# Altium schematic sheet 06_DRIVER.SchDoc
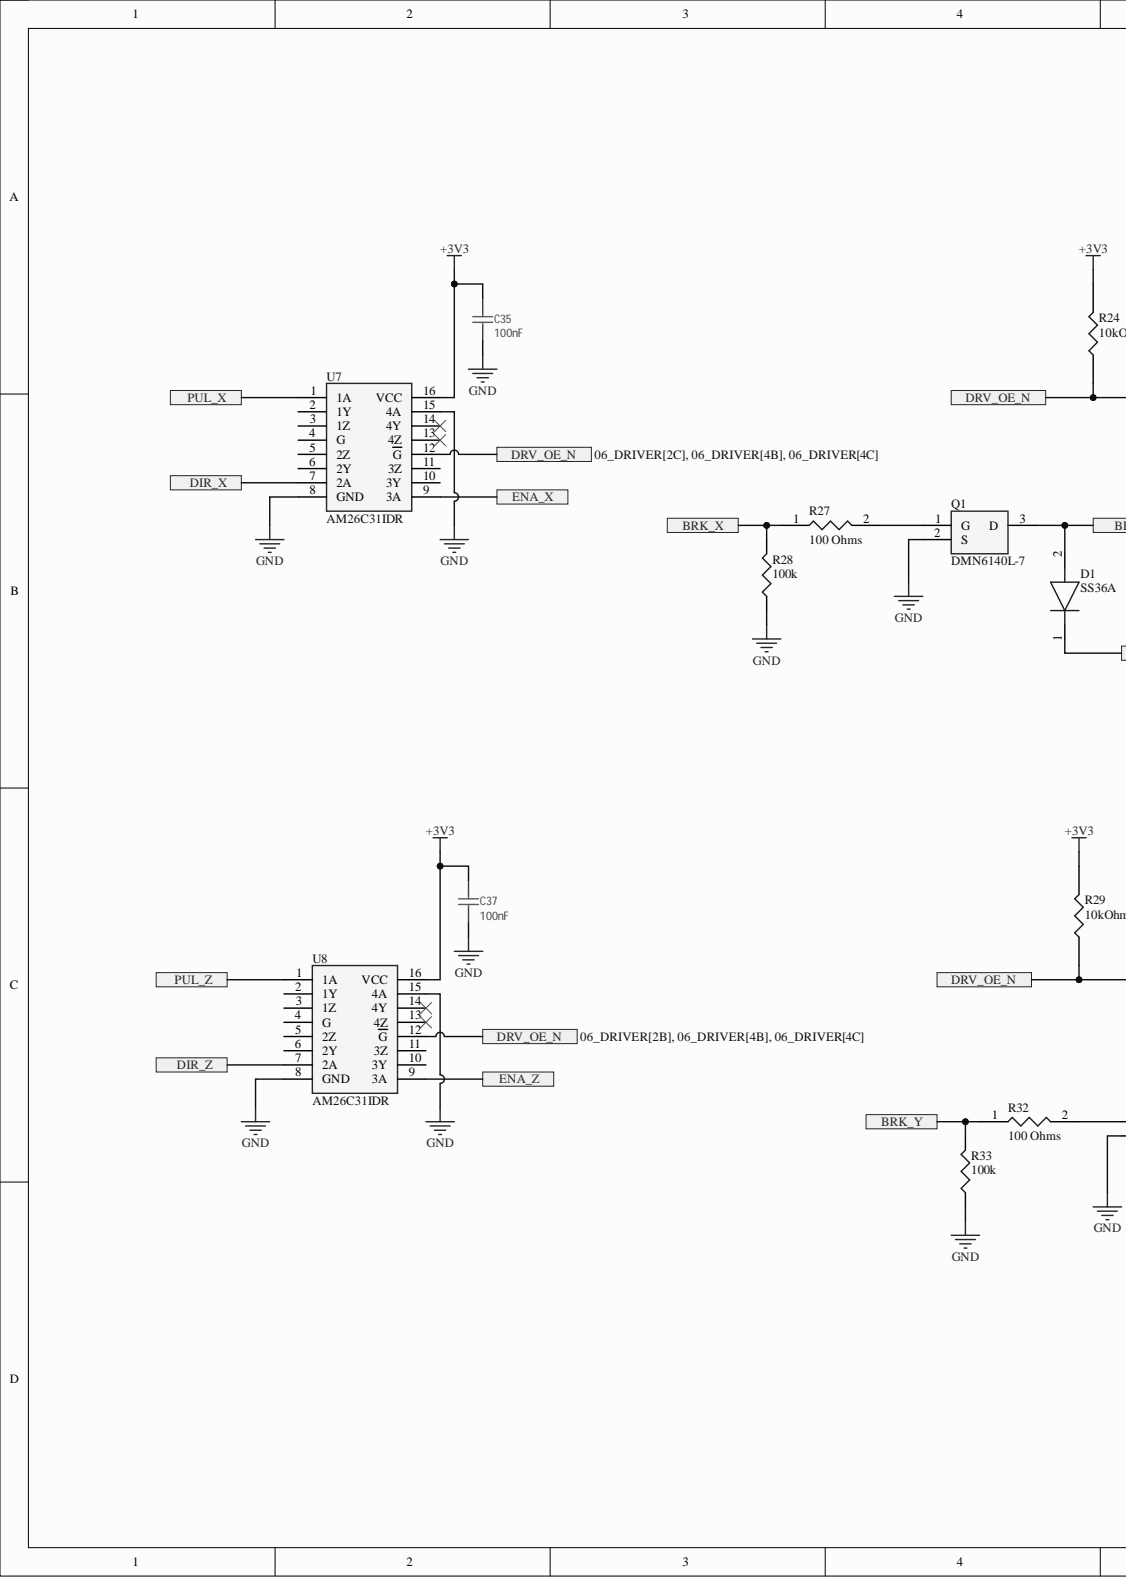
[diagram p0: 06_DRIVER.SchDoc, left]
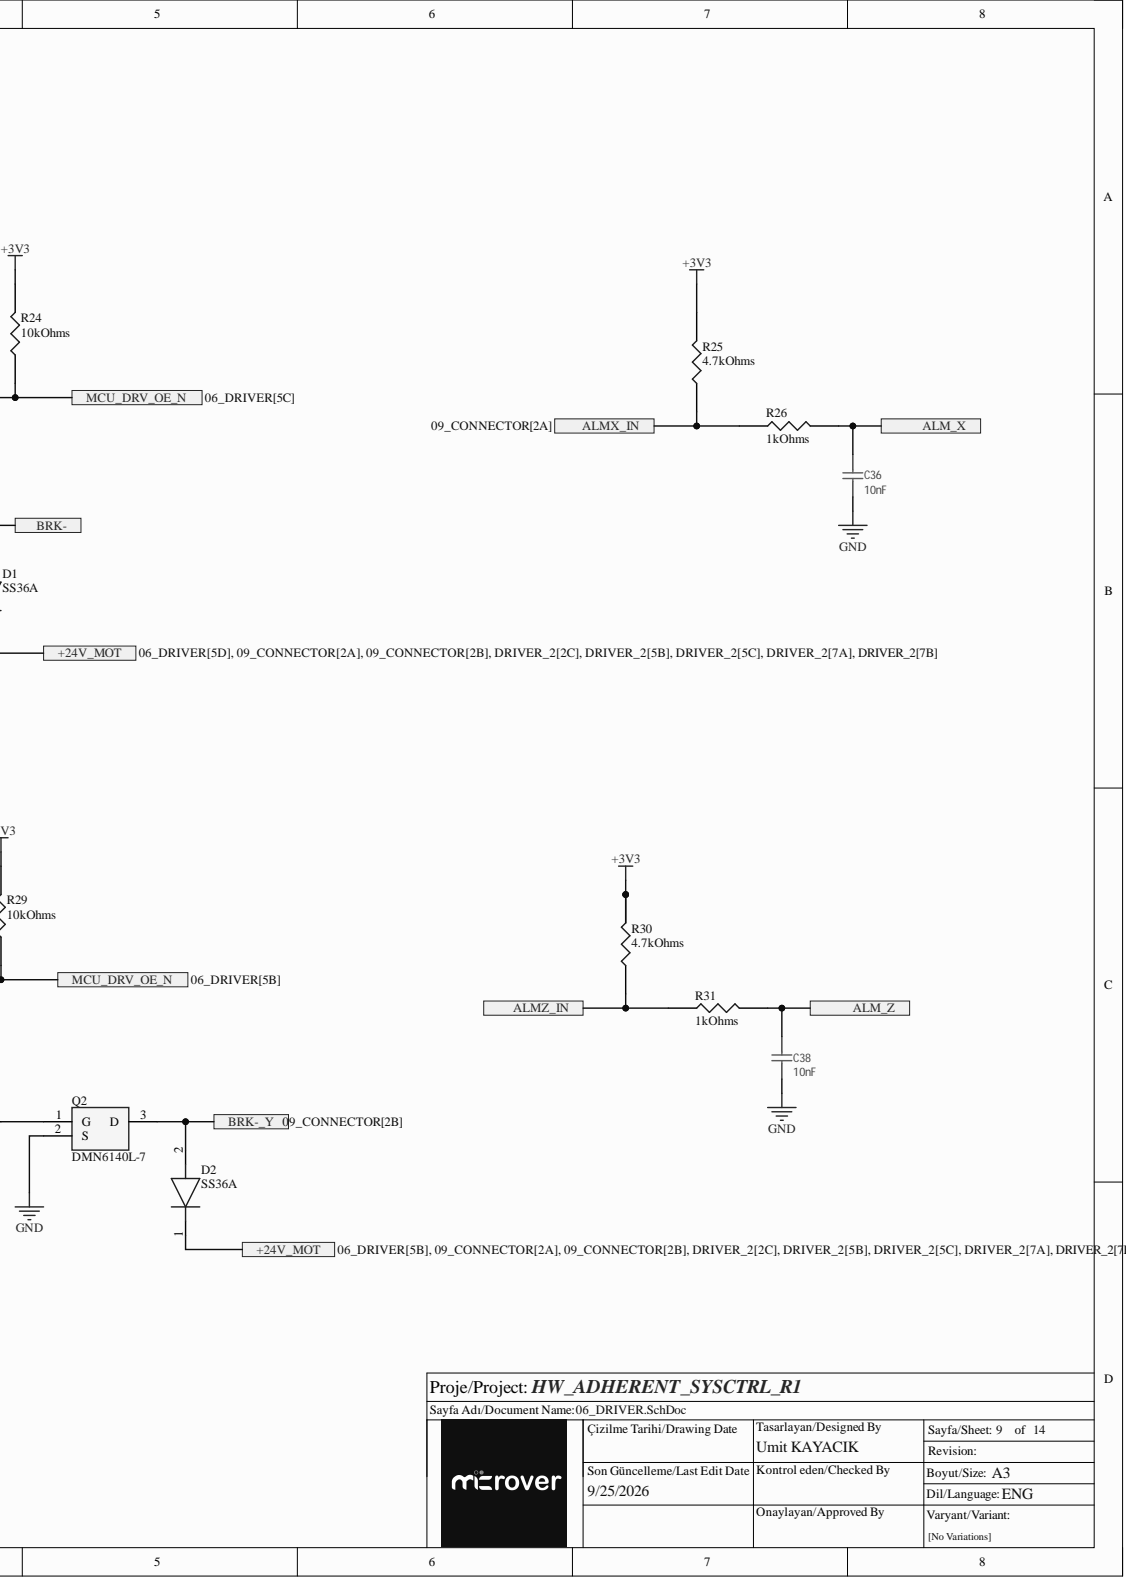
[diagram p1: 06_DRIVER.SchDoc, right]

## Parts
C35  100nF  [CAPC0603X03L_C]
C36  10nF  [CAPC0603X03L_C]
C37  100nF  [CAPC0603X03L_C]
C38  10nF  [CAPC0603X03L_C]
D1  SS36A  [DIOM5026X262N]
D2  SS36A  [DIOM5026X262N]
Q1  DMN6140L-7  [SOT96P240X110-3N]
Q2  DMN6140L-7  [SOT96P240X110-3N]
R24  10kOhms  [RESC0603X03L_C]
R25  4.7kOhms  [RES_0402]
R26  1kOhms  [RESC0603X03L_C]
R27  100 Ohms  [RESC1608X55N]
R28  value 100k  [SMR0603]  (hidden comment: RES 180K OHM 1% 1/10W 0603)
R29  10kOhms  [RESC0603X03L_C]
R30  4.7kOhms  [RES_0402]
R31  1kOhms  [RESC0603X03L_C]
R32  100 Ohms  [RESC1608X55N]
R33  value 100k  [SMR0603]  (hidden comment: RES 180K OHM 1% 1/10W 0603)
U7  AM26C31IDR  [SOIC127P600X175-16N]
U8  AM26C31IDR  [SOIC127P600X175-16N]

## Ports
+24V_MOT, ALM_X, ALM_Z, ALMX_IN, ALMZ_IN, BRK-, BRK-_Y, BRK_X, BRK_Y, DIR_X, DIR_Z, DRV_OE_N, ENA_X, ENA_Z, MCU_DRV_OE_N, PUL_X, PUL_Z

## Nets
+3V3: C35.2 C37.2 R24.2 R25.2 R29.2 R30.2 U7.16(VCC) U8.16(VCC)
GND: C35.1 C36.1 C37.1 C38.1 Q1.2 Q2.2 R28.1 R33.1 U7.8(GND) U7.15(4A) U8.8(GND) U8.15(4A)
+24V_MOT: D1.1 D2.1 ; port +24V_MOT
ALM_X: C36.2 R26.2 ; port ALM_X
ALM_Z: C38.2 R31.2 ; port ALM_Z
ALMX_IN: R25.1 R26.1 ; port ALMX_IN
ALMZ_IN: R30.1 R31.1 ; port ALMZ_IN
BRK-: D1.2 Q1.3 ; port BRK-
BRK-_Y: D2.2 Q2.3 ; port BRK-_Y
BRK_X: R27.1 R28.2 ; port BRK_X
BRK_Y: R32.1 R33.2 ; port BRK_Y
DIR_X: U7.7(2A) ; port DIR_X
DIR_Z: U8.7(2A) ; port DIR_Z
DRV_OE_N: R24.1 R29.1 U7.12(G) U8.12(G) ; port DRV_OE_N, port MCU_DRV_OE_N
ENA_X: U7.9(3A) ; port ENA_X
ENA_Z: U8.9(3A) ; port ENA_Z
PUL_X: U7.1(1A) ; port PUL_X
PUL_Z: U8.1(1A) ; port PUL_Z
NetQ1_1: Q1.1 R27.2
NetQ2_1: Q2.1 R32.2

Not connected: U7 2(1Y) 3(1Z) 4(G) 5(2Z) 6(2Y) 10(3Y) 11(3Z) 13(4Z) 14(4Y); U8 2(1Y) 3(1Z) 4(G) 5(2Z) 6(2Y) 10(3Y) 11(3Z) 13(4Z) 14(4Y)

## Library data of the parts
C35 C37 = C0201-100n: Capacitor, X5R, ±10%; Part number IPS: 00000001248, 00000002505; Part number NEMS: 100200078; Part number Norautron: 262071862; Artikkelnr Noca: N.A.; Component Status: Active; Note: 16V; Pad Count: 2; Component Class: Capacitors; Component Kind: Standard; ComponentLink1Description: Datasheet; ComponentLink1URL: N.A.; ComponentLink2Description: Supplier link; ComponentLink2URL: N.A.; Footprint Doc: 0201; HelpURL: N.A.; LatestRevisionDate: 2017-11-09; Manufacturer: N.A.; Manufacturer Part Number: N.A.; Part Number: C0201-100n; PartId: 100200078; Published: 2014-11-13; Supplier 1: N.A.; Supplier 2: N.A.; Supplier Part Number 1: N.A.; Supplier Part Number 2: N.A.; Value: 100nF; Author: rsk; Library Name: HW_ADHERENT_SYSCTRL_R1_L1.SchLib
C36 C38 = C0201-10n: Capacitor, X7R, ±10%; Part number IPS: 00000003480; Part number NEMS: 100200067; Part number Norautron: 26621779; Artikkelnr Noca: N.A.; Component Status: Active; Note: 10V; Pad Count: 2; Component Class: Capacitors; Component Kind: Standard; ComponentLink1Description: Datasheet; ComponentLink1URL: N.A.; ComponentLink2Description: Supplier link; ComponentLink2URL: N.A.; Footprint Doc: 0201; HelpURL: N.A.; LatestRevisionDate: 2017-11-09; Manufacturer: N.A.; Manufacturer Part Number: N.A.; Part Number: C0201-10n; PartId: 100200067; Published: 2014-11-13; Supplier 1: N.A.; Supplier 2: N.A.; Supplier Part Number 1: N.A.; Supplier Part Number 2: N.A.; Value: 10nF; Author: rsk; Library Name: HW_ADHERENT_SYSCTRL_R1_L1.SchLib
D1 D2 = SS36A: Diode; Manufacturer_Name: HY Electronic; Component Kind: Standard; Height: 2.62mm; Manufacturer_Part_Number: SS36A
Q1 Q2 = DMN6140L-7: Transistor; Manufacturer_Name: Diodes Incorporated; Arrow Part Number: DMN6140L-7; Arrow Price/Stock: https://www.arrow.com/en/products/dmn6140l-7/diodes-incorporated?utm_currency=USD&region=asia; Component Kind: Standard; Datasheet Link: https://www.diodes.com//assets/Datasheets/DMN6140L.pdf; Height: 1.1mm; Manufacturer_Part_Number: DMN6140L-7; Mouser Part Number: 621-DMN6140L-7; Mouser Price/Stock: https://www.mouser.co.uk/ProductDetail/Diodes-Incorporated/DMN6140L-7?qs=M%2FOdCRO8QQ0gki98nN7lXQ%3D%3D
R24 R29 = TNPW060310K0FHTA: 10 kOhms ±1% 0.1W, 1/10W Chip Resistor 0603 (1608 Metric) Automotive AEC-Q200 Thin Film; Manufacturer: Vishay Dale; Component Kind: Standard; Supplier: Digi; 541-3906-6-ND; Library Name: HW_ADHERENT_SYSCTRL_R1_L1.SchLib
R25 R30 = RT0402FRE074K7L: RES SMD 4.7K OHM 1% 1/16W 0402; Manufacturer: Yageo Group; Max Power Dissipation: 63mW; Power Rating: 62.5mW; Temperature Coefficient: 50ppm/°C; Voltage Rating: 50V; Case Code (Imperial): 0402; Case/Package: 0402; Category: Chip SMD Resistors; China RoHS: Non-Compliant; Component Kind: Standard; Composition: Thin Film; Contact Plating: Lead, Tin; Country of Origin: Mainland China; Export Control Classification Number (ECCN) Code: EAR99; Features: Moisture Resistant; Harmonized Tariff Schedule (HTS) Code: 8533.21.00.30; Height: 300µm; Introduction Date: 2008-06-09; Lead Free: Lead Free; Length: 1mm; Lifecycle Status: Production; Manufacturer Part Number: RT0402FRE074K7L; Max Operating Temperature: 155°C; Min Operating Temperature: -55°C; Mounting Technology: Surface Mount; Number of Terminals: 2; Number of Terminations: 2; Radiation Hardening: No; REACH SVHC: Yes; RoHS: Compliant; Schedule B: 8533210045, 8533210045|8533210045, 8533210045|8533210045|8533210045, 8533210045|8533210045|8533210045|8533210045; Termination: SMD/SMT; Tolerance: 1%; Value: 4.7kOhms; Voltage Rating (DC): 50V; Width: 500µm; Working Voltage: 50V; Library Name: HW_ADHERENT_SYSCTRL_R1_L1.SchLib
R26 R31 = CPF0603B1K0E: RES SMD 1K OHM 0.1% 1/16W 0603; Manufacturer: TE Connectivity; Manufacturer Lifecycle Status: ACTIVE; Max Power Dissipation: 63mW; Number of Resistors: 1; Operating Supply Voltage: 50V; Temperature Coefficient: 25ppm/°C; Case Code (Metric): 1608; Case/Package: 0603; Category: Chip SMD Resistors; China RoHS: Compliant; Component Kind: Standard; Composition: Thin Film; Depth: 800µm; ELV: Compliant; Export Control Classification Number (ECCN) Code: EAR99; Harmonized Tariff Schedule (HTS) Code: 8533.21.00.30; Height: 450µm; Introduction Date: 2009-09-20; Length: 1.55mm; Lifecycle Status: Production; Manufacturer Part Number: CPF0603B1K0E; Max Operating Temperature: 155°C; Min Operating Temperature: -55°C; Mounting Technology: Surface Mount; Number of Terminals: 2; Number of Terminations: 2; Packaging: Tape and Reel; Power Rating: 63mW; Radiation Hardening: No; REACH SVHC: Yes; RoHS: Compliant; Schedule B: 8533210045|8533210045|8533210045|8533210045|8533210045; Termination: Solder; Tolerance: 0.1%; Value: 1kOhms; Voltage Rating: 50V; Voltage Rating (DC): 50V; Weight: 2.012816mg; Width: 800µm; Working Voltage: 50V; Library Name: HW_ADHERENT_SYSCTRL_R1_L1.SchLib
R27 R32 = RC0603JR07100RL: Resistor; Manufacturer_Name: Yageo Group; Arrow Part Number: RC0603JR07100RL; Arrow Price/Stock: https://www.arrow.com/en/products/rc0603jr-07100rl/yageo; Component Kind: Standard; Datasheet Link: http://www.yageo.com/documents/recent/PYu-RC0603_51_RoHS_L_v5.pdf; Height: 0.55mm; Library Name: SamacSys.SchLib; Manufacturer_Part_Number: RC0603JR07100RL
R28 R33 = RES0603_100K_%1: 100 kOhms ±1% 0.1W, 1/10W Chip Resistor 0603 (1608 Metric) Moisture Resistant Thick Film; LCSC Manufacturer Part Number: RC0603FR-07100KL; Order Code: DIGI : 311-100KHRTR-ND; Component Kind: Standard; LCSC Part Number: C123419; Manufacturer Part Number: RC0603FR-07100KL; Library Name: HW_ADHERENT_SYSCTRL_R1_L2.SCHLIB
U7 U8 = AM26C31IDR: 4/0 Driver 16-SOIC; Manufacturer: Texas Instruments; Component Kind: Standard; Supplier: Digi; 296-24344-6-ND; Library Name: HW_ADHERENT_SYSCTRL_R1_L1.SchLib
